# Altium schematic sheet Backplane.SchDoc
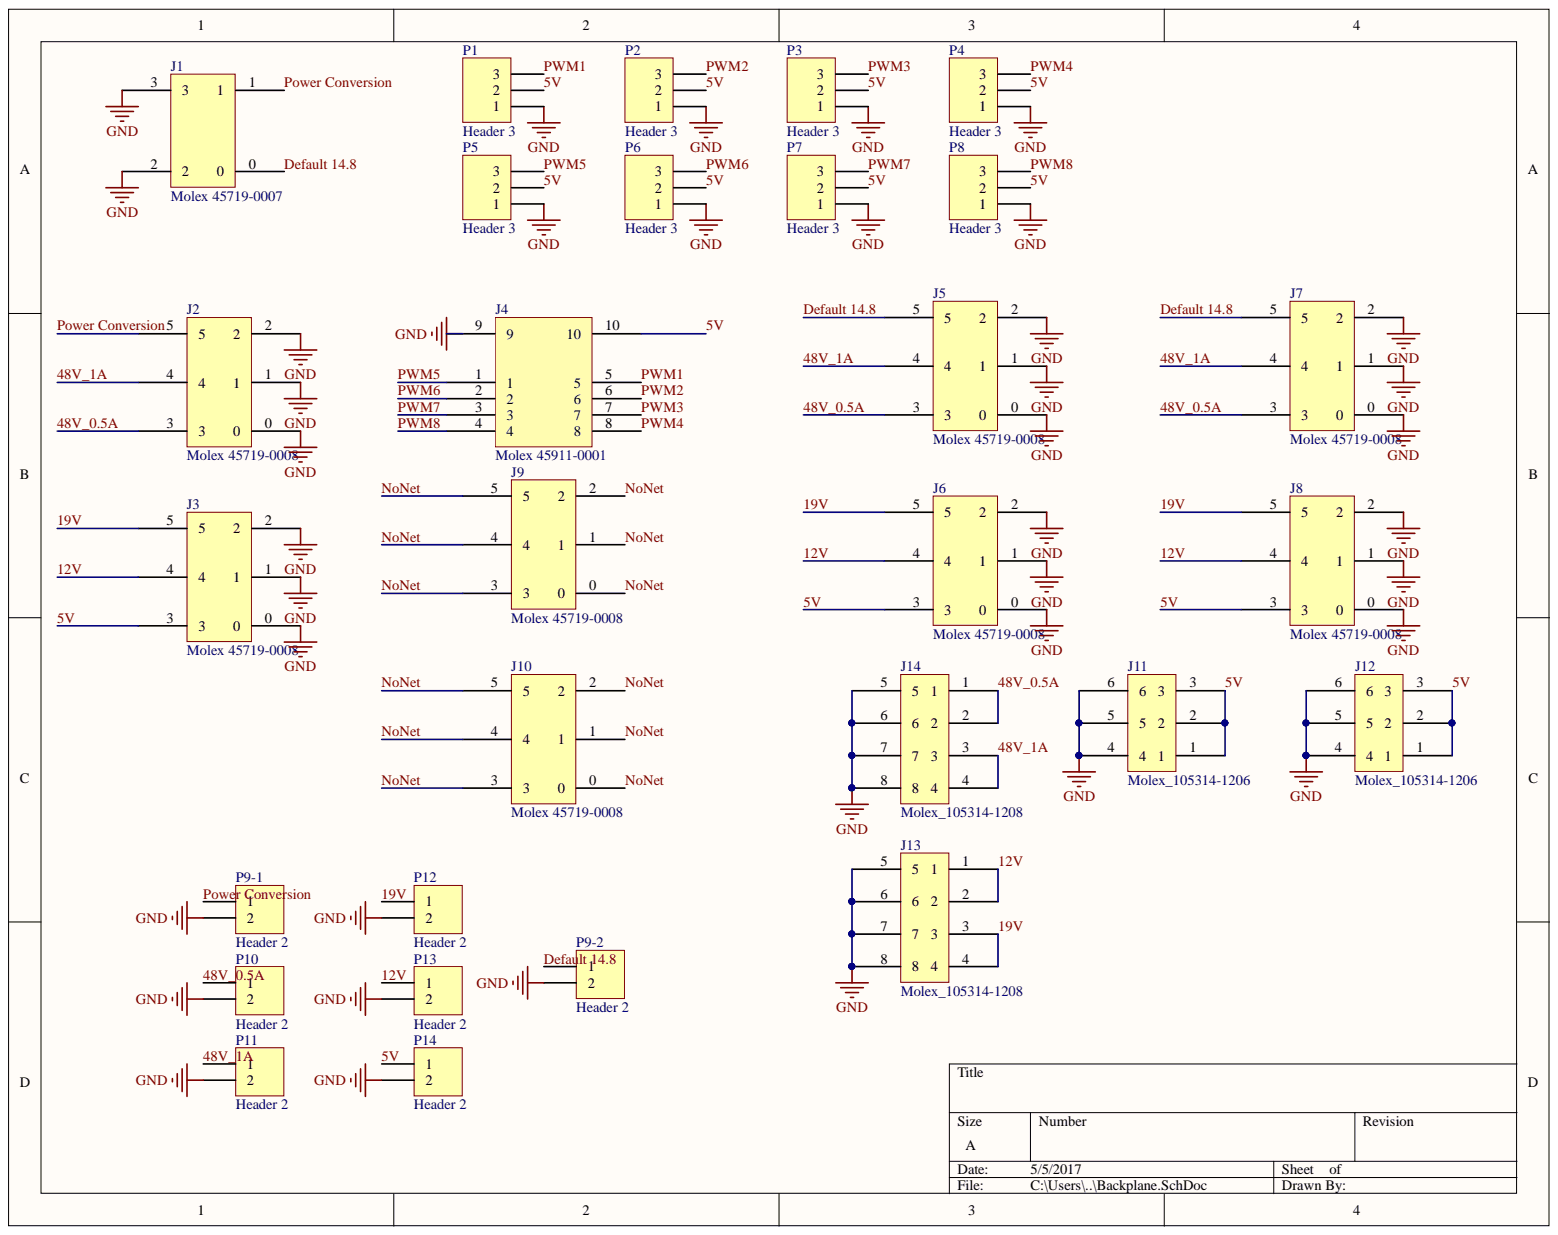
[diagram p0: Backplane.SchDoc]

## Parts
J1  Molex 45719-0007  [Molex_45719-0007]
J2  Molex 45719-0008  [Molex_45719-0008]
J3  Molex 45719-0008  [Molex_45719-0008]
J4  Molex 45911-0001  [Molex_45911-0004]
J5  Molex 45719-0008  [Molex_45719-0008]
J6  Molex 45719-0008  [Molex_45719-0008]
J7  Molex 45719-0008  [Molex_45719-0008]
J8  Molex 45719-0008  [Molex_45719-0008]
J9  Molex 45719-0008  [Molex_45719-0008]
J10  Molex 45719-0008  [Molex_45719-0008]
J11  Molex_105314-1206  [Molex_105314-1206]
J12  Molex_105314-1206  [Molex_105314-1206]
J13  Molex_105314-1208  [Molex_105314-1208]
J14  Molex_105314-1208  [Molex_105314-1208]
P1  Header 3  [HDR1X3]
P2  Header 3  [HDR1X3]
P3  Header 3  [HDR1X3]
P4  Header 3  [HDR1X3]
P5  Header 3  [HDR1X3]
P6  Header 3  [HDR1X3]
P7  Header 3  [HDR1X3]
P8  Header 3  [HDR1X3]
P9-1  Header 2  [HDR1X2]
P9-2  Header 2  [HDR1X2]
P10  Header 2  [HDR1X2]
P11  Header 2  [HDR1X2]
P12  Header 2  [HDR1X2]
P13  Header 2  [HDR1X2]
P14  Header 2  [HDR1X2]

## Nets
GND: J1.2 J1.3 J2.0 J2.1 J2.2 J3.0 J3.1 J3.2 J4.9 J5.0 J5.1 J5.2 J6.0 J6.1 J6.2 J7.0 J7.1 J7.2 J8.0 J8.1 J8.2 J11.4 J11.5 J11.6 J12.4 J12.5 J12.6 J13.5 J13.6 J13.7 J13.8 J14.5 J14.6 J14.7 J14.8 P1.1 P2.1 P3.1 P4.1 P5.1 P6.1 P7.1 P8.1 P9-1.2 P9-2.2 P10.2 P11.2 P12.2 P13.2 P14.2
5V: J3.3 J4.10 J6.3 J8.3 J11.1 J11.2 J11.3 J12.1 J12.2 J12.3 P1.2 P2.2 P3.2 P4.2 P5.2 P6.2 P7.2 P8.2 P14.1
12V: J3.4 J6.4 J8.4 J13.1 J13.2 P13.1
19V: J3.5 J6.5 J8.5 J13.3 J13.4 P12.1
48V_0.5A: J2.3 J5.3 J7.3 J14.1 J14.2 P10.1
48V_1A: J2.4 J5.4 J7.4 J14.3 J14.4 P11.1
DEFAULT 14.8: J1.0 J5.5 J7.5 P9-2.1
NONET: J9.0 J9.1 J9.2 J9.3 J9.4 J9.5 J10.0 J10.1 J10.2 J10.3 J10.4 J10.5
POWER CONVERSION: J1.1 J2.5 P9-1.1
PWM1: J4.5 P1.3
PWM2: J4.6 P2.3
PWM3: J4.7 P3.3
PWM4: J4.8 P4.3
PWM5: J4.1 P5.3
PWM6: J4.2 P6.3
PWM7: J4.3 P7.3
PWM8: J4.4 P8.3

## Library data of the parts
J13 J14 = Molex_105314-1210: Component Kind: Standard; Library Name: Molex_105314-1208.SchLib
P1-P8 = Header 3: Header, 3-Pin; Component Kind: Standard; LatestRevisionDate: 17-Jul-2002; LatestRevisionNote: Re-released for DXP Platform.; Library Name: Miscellaneous Connectors.IntLib; Signal Integrity: Connector; Publisher: Altium Limited
P9-1 P9-2 P10-P14 = Header 2: Header, 2-Pin; Component Kind: Standard; LatestRevisionDate: 17-Jul-2002; LatestRevisionNote: Re-released for DXP Platform.; Library Name: Miscellaneous Connectors.IntLib; Signal Integrity: Connector; Publisher: Altium Limited
J1 = Molex 45719-0007: Component Kind: Standard; Library Name: Molex_45719-0007.SchLib
J2 J3 J5-J10 = Molex 45719-0008: Component Kind: Standard; Library Name: Molex_45719-0008.SchLib
J4 = Molex 45911-0001: Component Kind: Standard; Library Name: Molex_45911-0004.SchLib
J11 J12 = Molex_105314-1206: Component Kind: Standard; Library Name: Molex_105314-1206.SchLib
